# Altium schematic sheet Power.SchDoc(Designator)
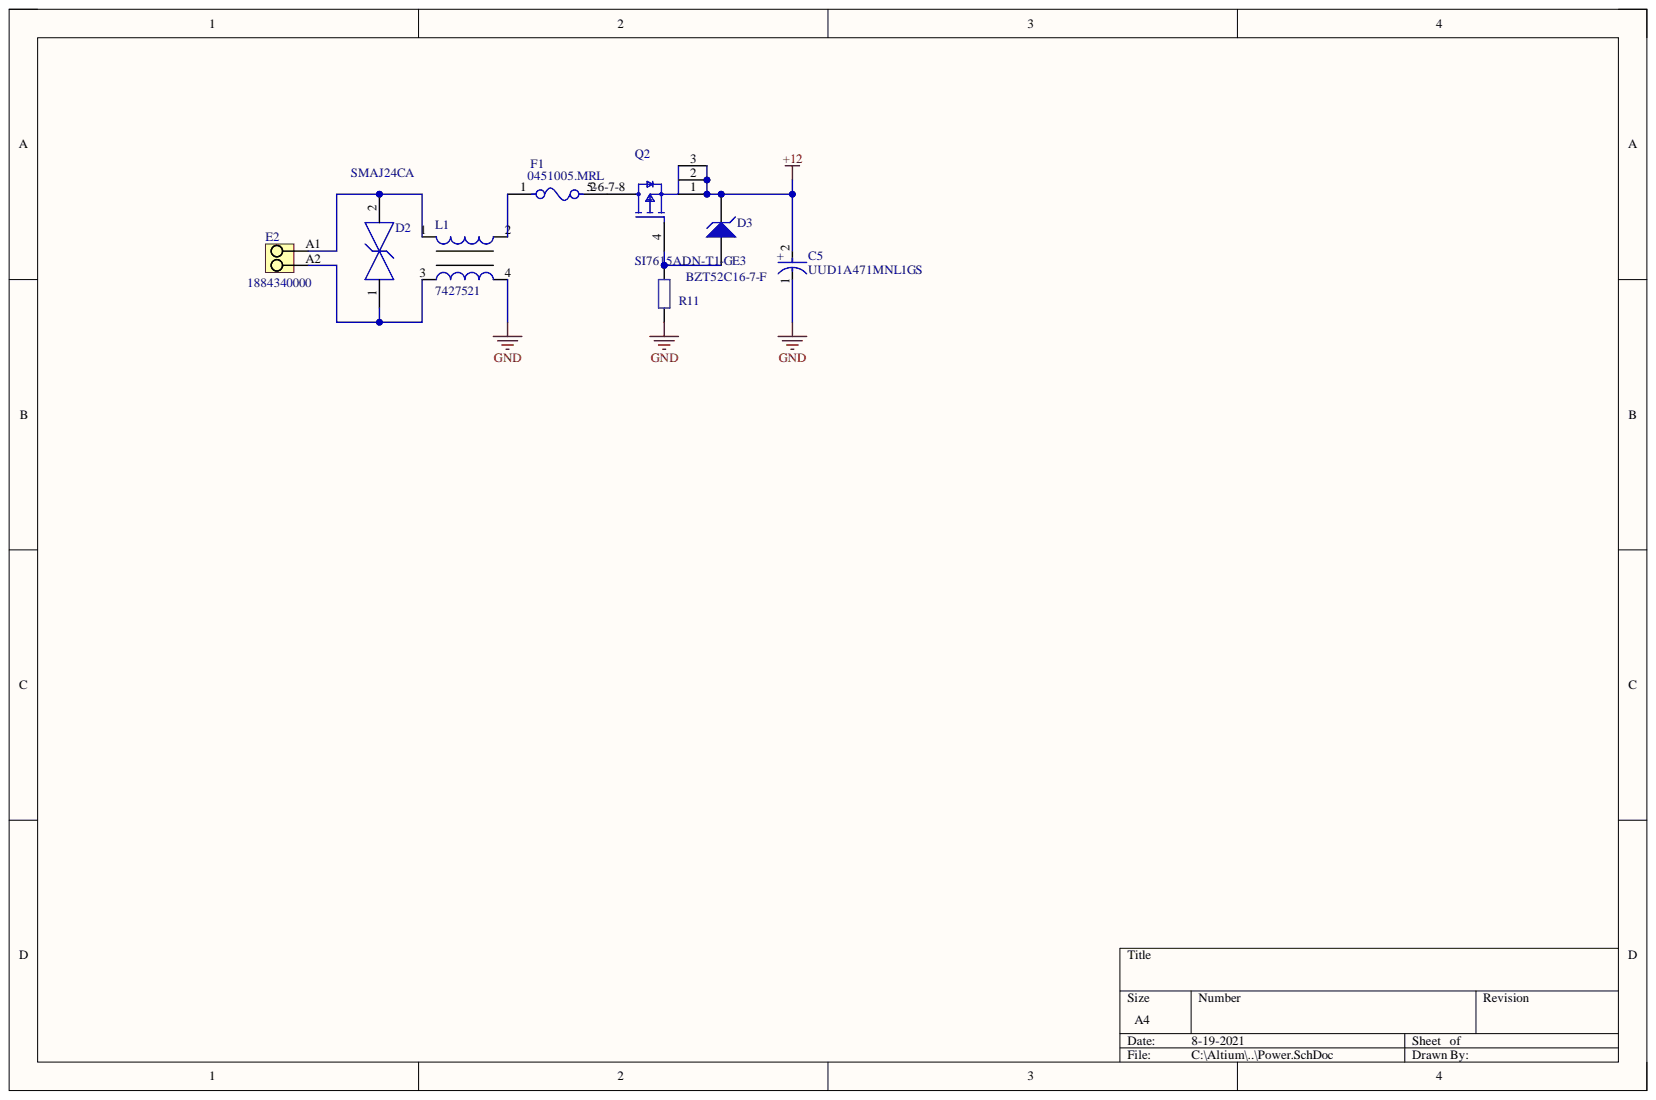
[diagram p0: Power.SchDoc]

## Parts
C5  UUD1A471MNL1GS  [FP-UUD1A471MNL1GS-MFG]
D2  SMAJ24CA  [FP-SMAJ-CA-MFG]
D3  BZT52C16-7-F  [DIOD-SOD123-2_V]
E2  1884340000  [LSF_SMT_5_00_135_02]
F1  0451005.MRL  [FP-0451-MFG]
L1  7427521  [WE-CMS]
Q2  SI7615ADN-T1-GE3  [FP-S16-2667_SINGLE-MFG]
R11  Res  [R0603 (1608)]  (value not drawn)

## Nets
+12: C5.2 D3.2 Q2.1 Q2.2 Q2.3
GND: C5.1 L1.4 R11.1
NetD2_1: D2.1 E2.A2 L1.3
NetD2_2: D2.2 E2.A1 L1.1
NetD3_1: D3.1 Q2.4 R11.2
NetF1_1: F1.1 L1.2
NetF1_2: F1.2 Q2.5-6-7-8

## Library data of the parts
C5 = CMP-0687-00070-2: CAP ALUM 470UF 20% 10V SMD; Dielectric Material: Aluminium; Dissipation Factor: 0.24%; Impedance: 170mR; Lead Diameter: 1.1mm; Leakage Current: 3uA; Life (Hours): 5000Hour; Ripple Current: 450mA; Capacitance: 470uF; Case/Package: Radial; Component Kind: Standard; Diameter: 8mm; Height: 10mm; Height - Seated (Max): 0.394inch; Lead Free: Lead Free; Lead Pitch: 3.1mm; Length: 10mm; Library Name: Altium Content Vault; Max Operating Temperature: 105°C; Min Operating Temperature: -55°C; Number of Pins: 2; Package Quantity: 500; Packaging: Tape and Reel; Radiation Hardening: No; REACH SVHC: No SVHC; Ripple Current (AC): 450mA; Termination: SMD/SMT; Tolerance: 20%; Voltage: 10V; Voltage Rating: 10V; Voltage Rating (DC): 10V
D2 = CMP-2000-07087-2: TVS DIODE 24V 38.9V DO214AC; Manufacturer: Littelfuse; Breakdown Voltage: 26.7V; Direction: Bidirectional; Max Junction Temp: +150°C; Number Of Channels: 1; Peak Pulse Current: 10.3A; Peak Pulse Power: 400W; Automotive: No; Category: Diode; Clamping Voltage: 38.9V; Component Kind: Standard; ComponentLink2Description: Datasheet; ComponentLink2URL: https://www.littelfuse.com/~/media/electronics/datasheets/tvs_diodes/littelfuse_tvs_diode_smaj_datasheet.pdf.pdf; Device Class L1: Circuit Protection; Device Class L2: TVS Diodes; Device Class L3: unset; Digikey Description: TVS DIODE 24V 38.9V DO214AC; Height: 2.29mm; Lead Free: Yes; Library Name: Altium Content Vault; Manufacturer Part Number: SMAJ24CA; Mouser Description: In a Pack of 25, Littelfuse SMAJ24CA, Bi-Directional TVS Diode, 400W, 2-Pin DO-214AC; Octopart: https://octopart.com/smaj24ca-littelfuse-350011; Package: SMAJ-CA; Reverse Standoff Voltage: 24V; RoHS: TRUE; Temperature: -65°C to +150°C; Temperature Range High: +150°C; Temperature Range Low: -65°C
D3 = CMP-0860-00001-1: Surface Mount Zener Diode, 16 V, -65 to 125 degC, SOD123, RoHS, Tape and Reel; Manufacturer: Diodes Inc; Maximum Reverse Current: 0.1 uA; Maximum Zener Impedance f: 1 kHz ZZK=200 Ohm; Power Max: 500 mW; Tol V: 5.56% Typ.; Voltage Zener: 16 V Typ.; Component Kind: Standard; ComponentLink1Description: Manufacturer URL; ComponentLink1URL: http://www.diodes.com/; ComponentLink2Description: Datasheet; ComponentLink2URL: http://www.diodes.com/datasheets/ds18004.pdf; ComponentLink3Description: Package Specification; ComponentLink3URL: http://www.diodes.com/datasheets/ap02002.pdf; DatasheetVersion: Rev. 37-2; Library Name: Altium Content Vault; Mounting Technology: Surface Mount; Operating Temperature: -65 to 150 degC; PackageDescription: 2-Pin SOD123, Body 2.7 x 1.55 mm, Height 1.45 mm; PackageReference: SOD123; PackageVersion: Rev. 79; Packing: Tape and Reel; PartNumber: BZT52C16-7-F; RoHS: TRUE
E2 = CMP-1559-02276-1: OMNIMATE Signal - series LSF; Manufacturer: Weidmueller; Contact_material: Cu-Leg; Contact_surface: tinned; Insulating_material: LCP GF; Mounting_onto_the_PCB: Reflow solder connection; No_of_poles: 2; Pitch_inch: 0,197 inch; Pitch_mm: 5 mm; Rated_current_min_no_of_poles_TU_20_deg_C: 19 A; Rated_current_use_group_B_UL_1059: 12 A; Rated_voltage_for_surge_voltage_class_pollution_degree_II_2: 500 V; Rated_voltage_use_group_B_UL_1059: 300 V; Short_text_for_material: LSF-SMT 5.00/02/135 3.5SN BK TU; Side_termination_characteristic: closed side; Solder_pin_length_mm: 3,5 mm; Solid_max_H05_07_V_U: 1,5 mm²; Solid_min_H05_07_V_U: 0,2 mm²; UL_94_flammability_rating: V-0; Wire_cross_section_AWG_max_UL_1059: AWG 16; Wire_cross_section_AWG_min_UL_1059: AWG 24; Colour: Black; Component Kind: Standard; ComponentLink1Description: Manufacturer URL; ComponentLink1URL: http://www.weidmueller.com/; ComponentLink2Description: URL Link1; ComponentLink2URL: http://catalog.weidmueller.com/catalog/Start.do?localeId=en&ObjectID=1884340000; Library Name: Altium Content Vault; PackageReference: LSF_SMT_5_00_135_02; Packaging: Tube; PartNumber: 1884340000; Qty: 60 PCS; Revision: V1
F1 = CMP-2000-05653-2: FUSE BRD MNT 5A 125VAC/VDC 2SMD; Manufacturer: Littelfuse Inc; Fuse Blow Speed: Fast; Fuse I2T: 5.566A2Sec; Fuse Interrupt Ac Current: 50A; Max Fuse Ac Voltage: 125V; Resistance: 0.0125Ω; Value: 0.0125Ω; Automotive: No; Category: Fuse; Component Kind: Standard; ComponentLink2Description: Datasheet; ComponentLink2URL: https://www.littelfuse.com/~/media/electronics/datasheets/fuses/littelfuse_fuse_451_453_datasheet.pdf.pdf; Device Class L1: Circuit Protection; Device Class L2: Fuses; Device Class L3: unset; Digikey Description: FUSE BRD MNT 5A 125VAC/VDC 2SMD; Fuse Current: 5A; Fuse Interrupt Dc Current: 50A; Height: 2.94mm; Lead Free: Yes; Library Name: Altium Content Vault; Manufacturer Part Number: 0451005.MRL; Max Fuse Dc Voltage: 125V; Mouser Description: Surface Mount Fuses 125V 5A; Octopart: https://octopart.com/0451005.mrl-littelfuse-566389; Package: 0451; RoHS: TRUE; Temperature: -55°C to +125°C; Temperature Range High: +125°C; Temperature Range Low: -55°C; Voltage Rating: 125V
L1 = CMP-0220-00057-1: Common Mode SMD Bead WE-CMS, Z = 52 Ohm; Impedance: 52 Ohm; Component Kind: Standard; ComponentLink1Description: Manufacturer Link; ComponentLink1URL: http://www.we-online.com; ComponentLink2Description: Datasheet; ComponentLink2URL: http://www.we-online.de/katalog/media/pdf/7427521.pdf; DatasheetVersion: ver 8, Jul-2010; Library Name: Altium Content Vault; PackageDescription: Inductor, 4-Leads, Body 8.5 x 5.6 mm; PackageReference: WE-CMS; PackageVersion: 2010
Q2 = CMP-02550-000167-1: MOSFET P-CH 20V 35A 1212-8S; Manufacturer: Vishay; Continuous Drain Current: -22.1A; Depletion Mode: False; Drain To Source Breakdown Voltage: -20V; Drain To Source Resistance: 0.0035Ω; Gate Charge At Vgs: 122nC @ -10V; Gate To Source Voltage: 12V; Input Capacitace At Vds: 5590pF @ -10V; Max Forward Diode Voltage: -1.1V; Max Junction Temp: +150°C; Number Of Channels: 1; Power Dissipation: 3.7W; Pulse Drain Current: -80A; Reverse Recovery Charge: 11nC; Reverse Recovery Time: 27ns; Rthja Max: 33°C/W; Standoff Height: 0mm; Threshold Vgs Max: -1.5V; Threshold Vgs Min: -0.4V; Transconductance: 82S; Turn Off Delay Time: 85ns; Turn On Delay Time: 13ns; Typ Forward Diode Voltage: -0.72V; Automotive: No; Category: Trans; Component Kind: Standard; ComponentLink2Description: Datasheet; ComponentLink2URL: http://www.vishay.com/docs/62667/si7615adn.pdf; Device Class L1: Discrete Semiconductors; Device Class L2: Transistors; Device Class L3: MOSFETs; Digikey Description: MOSFET P-CH 20V 35A 1212-8S; Drain To Source Voltage: -20V; Height: 1.12mm; Lead Free: Yes; Library Name: Altium Content Vault; Manufacturer Part Number: SI7615ADN-T1-GE3; Mouser Description: MOSFET -20V 4.4mOhm@10V 35A P-Ch G-III; Number Of P Channels: 1; Octopart: https://octopart.com/si7615adn-t1-ge3-vishay-45331111; Package: S16-2667_SINGLE; RoHS: TRUE; Temperature: -55°C to +150°C; Temperature Range High: +150°C; Temperature Range Low: -55°C
R11 = Resistor EU: Component Kind: Standard; Library Name: Moto Symbol Lib.SchLib
